# Altium schematic sheet Nano_Shunt_Thermistor_Photodiode.SchDoc
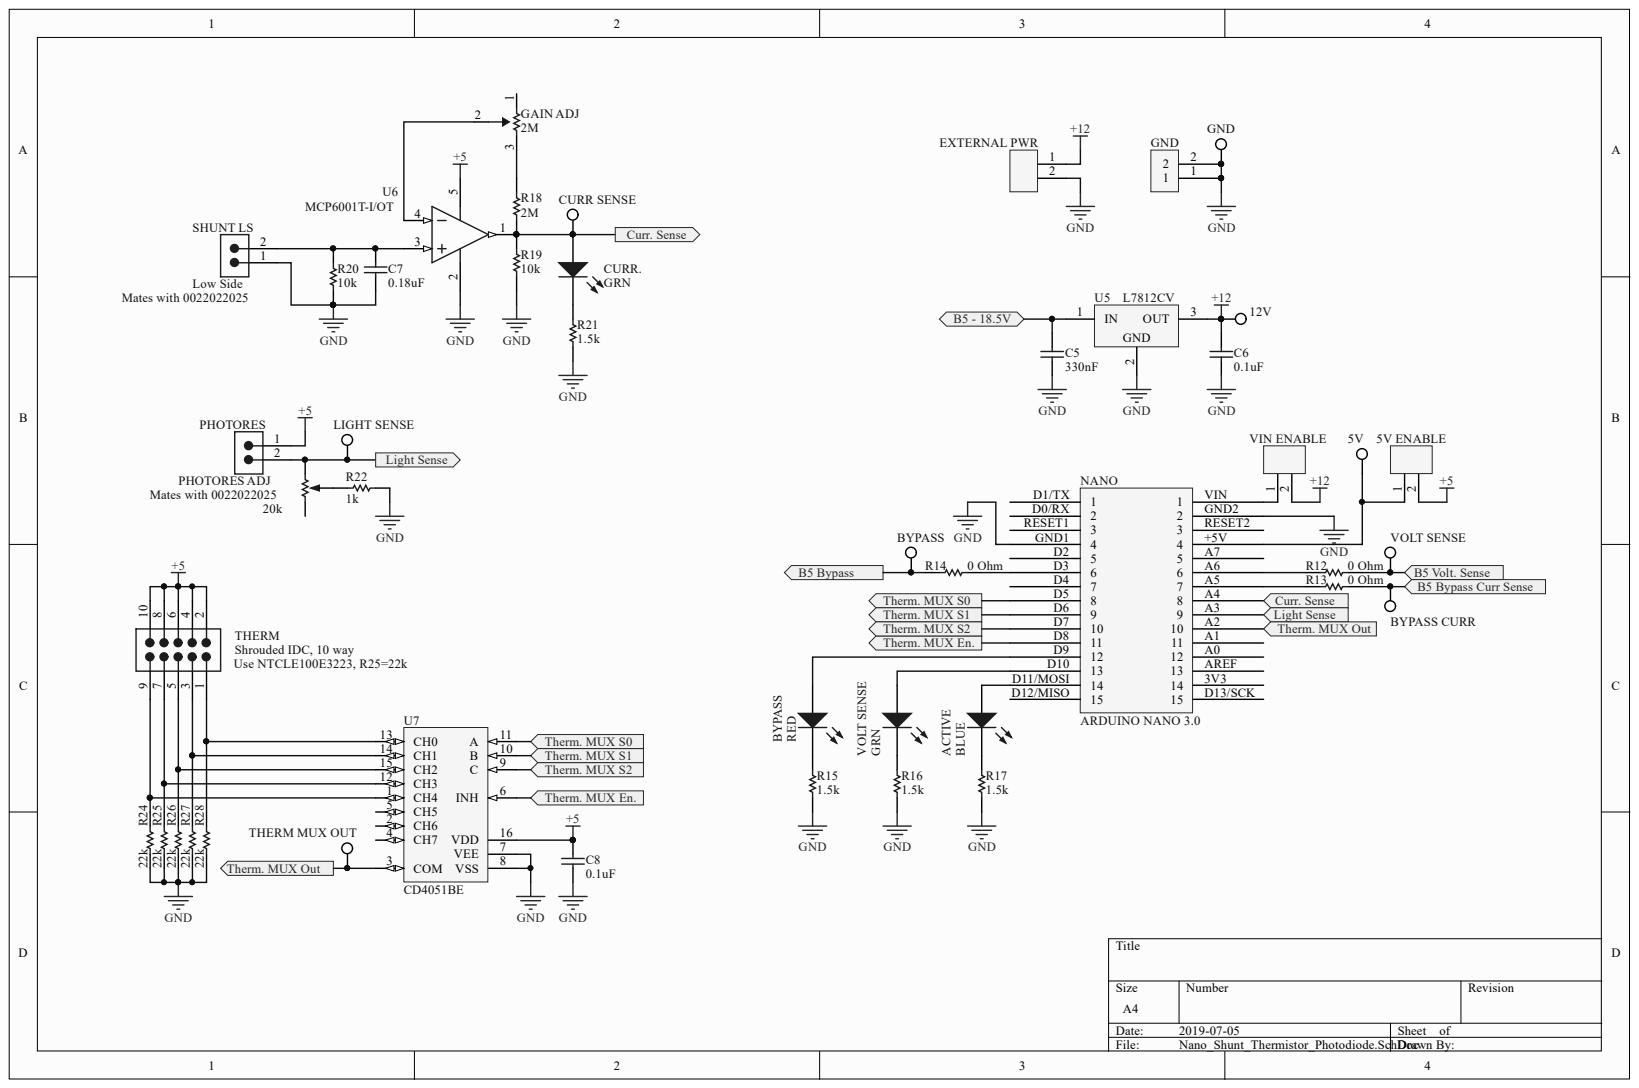
[diagram p0: Nano_Shunt_Thermistor_Photodiode.SchDoc]

## Parts
5V  BYPASS  [PCB-6rf74k5lqbtmzvabbodp-1]  (value not drawn)
5V_ENABLE  TE Connectivity 5-146281-2  [PCB-angfy19quc8nc8nc7d0m-1]  (value not drawn)
12V  BYPASS  [PCB-6rf74k5lqbtmzvabbodp-1]  (value not drawn)
ACTIVE  BLUE  [PCB-dnef8j46jbprf6b6erh1-1]
BYPASS  BYPASS  [PCB-6rf74k5lqbtmzvabbodp-1]
BYPASS  RED  [PCB-dnef8j46jbprf6b6erh1-1]
BYPASS_CURR  BYPASS  [PCB-6rf74k5lqbtmzvabbodp-1]
C5  330nF  [CAPC2013X140X45LL15T25]
C6  0.1uF  [CAPC2013X94X50LL20T25]
C7  0.18uF  [CAPC1608X90X35LL15T15]
C8  0.1uF  [PCB-fvggjhlky4an5us2gvhu-1]
CURR_SENSE  BYPASS  [PCB-6rf74k5lqbtmzvabbodp-1]  (value not drawn)
CURR.  GRN  [PCB-dnef8j46jbprf6b6erh1-1]
EXTERNAL_PWR  TE Connectivity 5-146281-2  [PCB-angfy19quc8nc8nc7d0m-1]  (value not drawn)
GAIN_ADJ  2M  [PCB-hse4uua1fjcnkyhyx9qc-1]
GND  Würth Elektronik 691137710002  [PCB-g2bho722h4u85oltew9j-1]  (value not drawn)
GND  BYPASS  [PCB-6rf74k5lqbtmzvabbodp-1]  (value not drawn)
LIGHT_SENSE  BYPASS  [PCB-6rf74k5lqbtmzvabbodp-1]  (value not drawn)
NANO  ARDUINO NANO 3.0  [PCBComponent_1]
PHOTORES  Pin 1 to GND  [PCB-fbv082iavlitmssgdmwr-1]  (value not drawn)
PHOTORES_ADJ  20k  [PCB-9c9st4rikhlhcybvfjyu-1]
R12  0 Ohm  [PCB-z4znfsbnblvo2vsusio9-1]
R13  0 Ohm  [PCB-z4znfsbnblvo2vsusio9-1]
R14  0 Ohm  [PCB-z4znfsbnblvo2vsusio9-1]
R15  1.5k  [RESC1608X55X30LL15T15]
R16  1.5k  [RESC1608X55X30LL15T15]
R17  1.5k  [RESC1608X55X30LL15T15]
R18  2M  [R0603_A]
R19  10k  [PCB-4abppfb21vtaf8x2o3vd-1]
R20  10k  [PCB-4abppfb21vtaf8x2o3vd-1]
R21  1.5k  [PCB-9cf7z3ce0dktpf6ltksy-1]
R22  1k  [CAPC1608X60X35NL10T15]
R24  22k  [RESC1608X55X25LL10T15]
R25  22k  [RESC1608X55X25LL10T15]
R26  22k  [RESC1608X55X25LL10T15]
R27  22k  [RESC1608X55X25LL10T15]
R28  22k  [RESC1608X55X25LL10T15]
SHUNT_LS  Low Side  [PCB-fbv082iavlitmssgdmwr-1]
THERM  Shrouded IDC, 10 way  [PCB-6gyt9jg7hir1kur10t9z-1]
THERM_MUX_OUT  BYPASS  [PCB-6rf74k5lqbtmzvabbodp-1]  (value not drawn)
U5  L7812CV  [PCB-ly41sd8ny0lgaceleunx-1]
U6  MCP6001T-I/OT  [SOT-23-OT5_L]
U7  CD4051BE  [PCB-wxkmxky2o49f0ip7fec3-1]
VIN_ENABLE  TE Connectivity 5-146281-2  [PCB-angfy19quc8nc8nc7d0m-1]  (value not drawn)
VOLT_SENSE  BYPASS  [PCB-6rf74k5lqbtmzvabbodp-1]  (value not drawn)
VOLT_SENSE  GRN  [PCB-dnef8j46jbprf6b6erh1-1]

## Ports
B5 - 18.5V, B5 BYPASS, B5 BYPASS CURR SENSE, B5 VOLT. SENSE, CURR. SENSE, LIGHT SENSE, THERM. MUX EN., THERM. MUX OUT, THERM. MUX S0, THERM. MUX S1, THERM. MUX S2

## Nets
+5: 5V_ENABLE.2 C8.1 PHOTORES.1 THERM.2 THERM.4 THERM.6 THERM.8 THERM.10 U6.5 U7.16(VDD)
+12: 12V.TP C6.1 EXTERNAL_PWR.1 U5.3 VIN_ENABLE.2
GND: C5.2 C6.2 C7.1 C8.2 EXTERNAL_PWR.2 GND.1 GND.2 GND.TP NANO.GND1 NANO.GND2 R15.1 R16.1 R17.1 R19.2 R20.1 R21.2 R22.2 R24.1 R25.1 R26.1 R27.1 R28.1 SHUNT_LS.1 U5.2 U6.2 U7.7(VEE) U7.8(VSS)
B5 - 18.5V: C5.1 U5.1 ; port B5 - 18.5V
Net5V_TP: 5V.TP 5V_ENABLE.1 NANO.+5V
NetACTIVE_1: ACTIVE.1 NANO.D11/MOSI
NetACTIVE_2: ACTIVE.2 R17.2
NetBYPASS CURR_TP: BYPASS_CURR.TP R13.2 ; port B5 BYPASS CURR SENSE
NetBYPASS_1: BYPASS.1 NANO.D9
NetBYPASS_2: BYPASS.2 R15.2
NetBYPASS_TP: BYPASS.TP R14.1 ; port B5 BYPASS
NetC7_2: C7.2 R20.2 SHUNT_LS.2 U6.3
NetCURR._1: CURR_SENSE.TP CURR..1 NANO.A4 R18.1 R19.1 U6.1 ; port CURR. SENSE
NetCURR._2: CURR..2 R21.1
NetGAIN ADJ_2: GAIN_ADJ.2 U6.4
NetGAIN ADJ_3: GAIN_ADJ.3 R18.2
NetLIGHT SENSE_TP: LIGHT_SENSE.TP NANO.A3 PHOTORES.2 PHOTORES_ADJ.3 ; port LIGHT SENSE
NetNANO_A2: NANO.A2 THERM_MUX_OUT.TP U7.3(COM) ; port THERM. MUX OUT
NetNANO_A5: NANO.A5 R13.1
NetNANO_A6: NANO.A6 R12.1
NetNANO_D3: NANO.D3 R14.2
NetNANO_D5: NANO.D5 U7.11(A) ; port THERM. MUX S0
NetNANO_D6: NANO.D6 U7.10(B) ; port THERM. MUX S1
NetNANO_D7: NANO.D7 U7.9(C) ; port THERM. MUX S2
NetNANO_D8: NANO.D8 U7.6(INH) ; port THERM. MUX EN.
NetNANO_D10: NANO.D10 VOLT_SENSE.1
NetNANO_VIN: NANO.VIN VIN_ENABLE.1
NetPHOTORES ADJ_2: PHOTORES_ADJ.2 R22.1
NetR12_2: R12.2 VOLT_SENSE.TP ; port B5 VOLT. SENSE
NetR16_2: R16.2 VOLT_SENSE.2
NetR24_2: R24.2 THERM.9 U7.1(CH4)
NetR25_2: R25.2 THERM.7 U7.12(CH3)
NetR26_2: R26.2 THERM.5 U7.15(CH2)
NetR27_2: R27.2 THERM.3 U7.14(CH1)
NetR28_2: R28.2 THERM.1 U7.13(CH0)

Not connected: GAIN_ADJ 1; NANO 3V3 A0 A1 A7 AREF D0/RX D1/TX D2 D4 D12/MISO D13/SCK RESET1 RESET2; PHOTORES_ADJ 1; U7 2(CH6) 4(CH7) 5(CH5)

## Library data of the parts
5V 12V BYPASS BYPASS_CURR CURR_SENSE GND LIGHT_SENSE THERM_MUX_OUT VOLT_SENSE = 5002: KEYSTONE   5002   Series:-; Lead-Free Status: Lead Free; Color: White; Component Kind: Standard; Contact Material: Phosphor Bronze; Contact Plating: Tin; Library Name: Octopart library; Manufacturer: Keystone; Manufacturer Part Number: 5002; OctopartID: f34a3a40c2652c8d; Octopart Url: https://octopart.com/5002-keystone-5193; Packaging: Bag; REACH SVHC Compliance: No SVHC; RoHS: Compliant; Size-Diameter: 2.54 mm; Size-Height: 7.62 mm; Size-Inner Diameter: 1.27 mm; Size-Length: 7.62 mm
5V_ENABLE EXTERNAL_PWR VIN_ENABLE = 5-146281-2: Header; Breakaway; 0.100 in.; PC Board; Single; 2; 0.025 in.; 0.12 in.; 3 A; ELV Compliant: Compliant; Gender: Male; Number of Contacts: 2; Component Kind: Standard; Contact Material: Copper Alloy; Flammability Rating: UL 94 V-0; Housing Color: Black; Library Name: Octopart library; OctopartID: 39c9f206a0b6e60e
ACTIVE CURR. VOLT_SENSE = APT2012LZGCK: 2.0x1.2mm Low Current Green; Component Kind: Standard; Library Name: Octopart library; Manufacturer Part Number: APT2012LZGCK; OctopartID: 03a5c9cd5237dc62; Octopart URL: http://octopart.com/apt2012lzgck-kingbright-56784116; RoHS: Compliant
BYPASS = APT2012LSECK/J3-PRV: 2.0x1.2mm Low Current Red; Component Kind: Standard; Library Name: Octopart library; Manufacturer Part Number: APT2012LSECK/J3-PRV; OctopartID: ea112079bb8735d6; Octopart URL: http://octopart.com/apt2012lseck%2Fj3-prv-kingbright-56784112; RoHS: Compliant
C5 = GCM21BL81H334KA56L: CAP CER 0.33UF 50V 10% X8L 0805; Capacitance: 3.3e-07 F; Voltage Rating (DC): 50.0 V; Capacitance Tolerance: ±10%; Case/Package: 0805; Component Kind: Standard; Dielectric Characteristic: X8L; Library Name: Octopart library; Manufacturer Part Number: GCM21BL81H334KA56L; OctopartID: 3684fe81a36ce943; Octopart Url: https://octopart.com/gcm21bl81h334ka56l-murata-17805454; RoHS: Compliant
C6 = 08055C104KAZ2A: CAP CER 0.1UF 50V 10% X7R 0805; Voltage Rating (DC): 50.0 V; Capacitance Tolerance: ±10%; Component Kind: Standard; Library Name: Octopart library; Mounting Style: Surface Mount; Number of Pins: 2; Octopart Url: https://octopart.com/08055c104kaz2a-avx+interconnect+%2F+elco-195624; REACH SVHC Compliance: No SVHC; RoHS: Compliant
C7 = 0603ZC184KAT2A: CAP CER 0.18UF 10V 10% X7R 0603; Capacitance: 1.8e-07 F; Lead-Free Status: Lead Free; Voltage Rating (DC): 10.0 V; Capacitance Tolerance: ±10%; Case/Package: 0603; Component Kind: Standard; Dielectric Characteristic: X7R; Library Name: Octopart library; Manufacturer Part Number: 0603ZC184KAT2A; Mounting Style: Surface Mount; Number of Pins: 2; OctopartID: 5062996a8c178fa5; Octopart Url: https://octopart.com/0603zc184kat2a-avx+interconnect+%2F+elco-194835; Packaging: Cut Tape (CT); REACH SVHC Compliance: No SVHC; RoHS: Compliant; Size-Height: 0.00086 m; Size-Length: 0.0016 m; Size-Width: 0.00081 m
C8 = CC0603KRX7R8BB104: 0603 0.1 uF 25 V ±10 % Tolerance X7R SMT Multilayer Ceramic Capacitor; Component Kind: Standard; Halogen Free Status: Halogen Free; Library Name: Octopart library; OctopartID: 56da9fafa36a891d
GAIN_ADJ = 3296W-1-205LF: Res Cermet Trimmer 2M Ohm 10% 1/2W 25(Elec)Turn 2.19mm (9.53 X 4.83 X 11.55mm) Pin Thru-Hole Tube; Lead-Free Status: Lead Free; Temperature Coefficient: -100 ppm/°C to 100 ppm/°C; Voltage Rating (DC): 300 V; Color: Blue; Component Kind: Standard; Library Name: Octopart library; Mounting Style: Through Hole; OctopartID: d21d1b1f32ff0dda; Octopart URL: https://octopart.com/3296w-1-205lf-bourns-574852; Operating Temperature: -55.0 °C to 150 °C; Packaging: Tube; REACH SVHC Compliance: No SVHC; Resistance Tolerance: ±10%; Size-Height: 10.0 mm; Size-Length: 9.52 mm; Size-Width: 4.83 mm; Weight: 851 mg
GND = 691137710002: Series 1377 - 5.00 mm Horizontal Entry with Pressure Clamp WR-TBL, 2 pin; Pin Pitch: 5.00 mm; Component Kind: Standard; Library Name: Octopart library; Manufacturer Part Number: 691137710002; Mounting Style: Through Hole; Number of Pins: 2; Octopart URL: https://octopart.com/691137710002-w%C3%BCrth+elektronik-78871135; Operating Temperature: 120 °C (max)
NANO = ARDUINO NANO 3.0: Lead-Free Status: Lead Free; Component Kind: Standard; Description: Arduino Nano 3.0; Library Name: Octopart library; OctopartID: 1f01d29ed047c0c6; Octopart URL: https://octopart.com/arduino+nano+3.0-arduino-76933664; RoHS: Compliant
PHOTORES SHUNT_LS = 22-05-3021: KK® 254 Solid Header, Right Angle, with Friction Lock, 2 Circuits, Tin (Sn) Plating; Current Rating: 4.00 A; Gender: Male; Glow Wire Compliant: Non-Compliant; Lead-Free Status: Lead Free; Number of Circuits: 2; Pin Pitch: 2.54 mm; Color: White; Component Kind: Standard; Contact Material: Brass; Contact Plating: Tin; Halogen Free Status: Low Halogen; Housing Color: White; Housing Material: Nylon; Library Name: Octopart library; Lifecycle Status: Active; Manufacturer Part Number: 22-05-3021; Mounting Style: Through Hole; Number of Contacts: 2; Number of Positions: 2; Number of Rows: 1; OctopartID: 2cb3e5b918c4f374; Octopart URL: http://octopart.com/22-05-3021-molex-4369; Orientation: Right Angle; Packaging: Bulk; RoHS: Compliant; Size-Height: 6.35 mm; Size-Length: 5.08 mm; Size-Width: 8.18 mm
PHOTORES_ADJ = 3296Y-1-203LF: Pot; Power Rating: 500 mW; Resistance: 20.0 kΩ; Resistance Tolerance: ±10%; Temperature Coefficient: -100 ppm/°C to 100 ppm/°C; Voltage Rating (DC): 300 V; Color: Blue; Component Kind: Standard; Library Name: Octopart library; Manufacturer Part Number: 3296Y-1-203LF; Mounting Style: Through Hole; OctopartID: dacc13c74ec9050b; Octopart URL: https://octopart.com/3296y-1-203lf-bourns-110947; Operating Temperature: -55.0 °C to 150 °C; Packaging: Tube; REACH SVHC Compliance: No SVHC; Size-Length: 9.53 mm; Size-Width: 4.83 mm; Weight: 851 mg
R12-R14 = RC0603JR-070RL: RC Series 0603 0.1 W 0 O Jumper Surface Mount Thick Film Chip Resistor; Component Kind: Standard; Library Name: Octopart library
R15-R17 = ERJ-3GEYJ152V: Thick Film Resistors - SMD 0603 1.5Kohms 5% Tol; Lead-Free Status: Lead Free; Power Rating: 0.1 W; Resistance: 1500.0 Ω; Voltage Rating (DC): 75.0 V; Case/Package: 0603; Component Kind: Standard; Library Name: Octopart library; Lifecycle Status: Not Listed by Manufacturer; Manufacturer Part Number: ERJ-3GEYJ152V; Mounting Style: Surface Mount; OctopartID: 3d27f760b46fe2e0; Octopart Url: https://octopart.com/erj-3geyj152v-panasonic-55421998; Packaging: Cut Tape (CT); REACH SVHC Compliance: No SVHC; Resistance Tolerance: ±5%; RoHS: Compliant; Size-Height: 0.00045 m; Size-Length: 0.0016 m; Size-Width: 0.0008 m
R18 = RC0603FR-072ML: RC Series 0603 0.1 W 2 MOhms 1% ±100 ppm/°C SMT Thick Film Chip Resistor; Lead-Free Status: Lead Free; Power Rating: 0.1 W; Resistance: 2000000.0 Ω; Voltage Rating (DC): 50.0 V; Component Kind: Standard; Library Name: Octopart library; Manufacturer Part Number: RC0603FR-072ML; OctopartID: 4a8d83938e51954a; Octopart Url: http://octopart.com/rc0603fr-072ml-yageo-1368506; Resistance Tolerance: ±1%; RoHS: Compliant; Seller Landing  Url: https://octopart-clicks.com/click/track?country=US&ak=8157f166&sig=0a542fb&sid=5489&ppid=1368506&vpid=64008051&ct=offers
R19 R20 = CRCW060310K0FKEAHP: RES SMD 10K OHM 1% 1/4W 0603; Component Kind: Standard; Library Name: Octopart library; Octopart Url: https://octopart.com/crcw060310k0fkeahp-vishay-42634028
R21 = ERJ-PA3F1501V: Res Thick Film 0603 1.5K Ohm 1% 0.25W(1/4W) }100ppm/C Molded SMD Automotive Punched T/R; Case/Package (SI): 1608; Lead-Free Status: Lead Free; Component Kind: Standard; Library Name: Octopart library
R22 = CR0603-FX-1001ELF: Res Thick Film 0603 1K Ohm 1% 1/10W ±100ppm/°C Molded SMD SMD Paper Tape on Plastic Reel; Resistance: 1.00 kΩ; Temperature Coefficient: -100 ppm/°C to 100 ppm/°C; Component Kind: Standard; Library Name: Octopart library; Size-Length: 1.60 mm
R24-R28 = RT0603BRD0722KL: Thin Film Resistors 1/10W 22K ohm .1% 25ppm; Lead-Free Status: Lead Free; Power Rating: 0.1 W; Resistance: 22000.0 Ω; Resistance Tolerance: ±0.1%; Voltage Rating (DC): 50.0 V; Case/Package: 0603; Component Kind: Standard; Library Name: Octopart library; Manufacturer Part Number: RT0603BRD0722KL; Mounting Style: Surface Mount; OctopartID: 3decf4f05313e22b; Octopart Url: https://octopart.com/rt0603brd0722kl-yageo-1461145; RoHS: Compliant; Size-Height: 0.00045 m; Size-Length: 0.0016 m; Size-Width: 0.0008 m
THERM = 302-R101: Conn Socket Strip SKT 10 POS 2.54mm Solder RA Thru-Hole; Color: Black; Component Kind: Standard; Library Name: Octopart library; Manufacturer Part Number: 302-R101; Mounting Style: Through Hole; Octopart URL: https://octopart.com/302-r101-on+shore+technology-21363897; Packaging: Tray
U5 = L7812CV: Positive Voltage Regulator, 12V, 3-Pin TO-220; Number of Outputs: 1; Output Current: 1.5 A; Case/Package: TO-220; Component Kind: Standard; Library Name: Octopart library; Lifecycle Status: Active; Mounting Style: Through Hole; OctopartID: eed4249398353113; Octopart Url: https://octopart.com/l7812cv-stmicroelectronics-55399303; Packaging: Tube; REACH SVHC Compliance: No SVHC; RoHS: Compliant
U6 = MCP6001T-I/OT: 1 MHz, Low-Power Operational Amplifier, 5-Pin SOT-23, Industrial Temperature, Tape and Reel; Bandwidth: 1000000.0 Hz; Input Offset Drift: 2e-06 V/K; Lead-Free Status: Lead Free; Number of Channels: 1; Slew Rate: 0.6 V/μs; Case/Package: SOT-23; Component Kind: Standard; Gain Bandwidth Product: 1000000.0 Hz; Library Name: Octopart library; Lifecycle Status: Active; Manufacturer Part Number: MCP6001T-I/OT; Mounting Style: Surface Mount; Number of Circuits: 1; Number of Pins: 5; OctopartID: 08dac1d1603ad71d; Octopart Url: https://octopart.com/mcp6001t-i%2Fot-microchip-85382; REACH SVHC Compliance: No SVHC; RoHS: Compliant
U7 = CD4051BE: CD4051BE MULTIPLEXER, 8 CHANNEL, DIP-16; Lead-Free Status: Lead Free; Number of Bits: 8; Number of Circuits: 1; Component Kind: Standard; Library Name: Octopart library; Manufacturer Part Number: CD4051BE; Mounting Style: Through Hole; Number of Channels: 8; Number of Outputs: 8; Number of Pins: 16; Octopart Url: http://octopart.com/cd4051be-texas+instruments-7481; Packaging: Tube; RoHS: Compliant
